# Altium schematic sheet 08_CF_INTERFACE.SchDoc
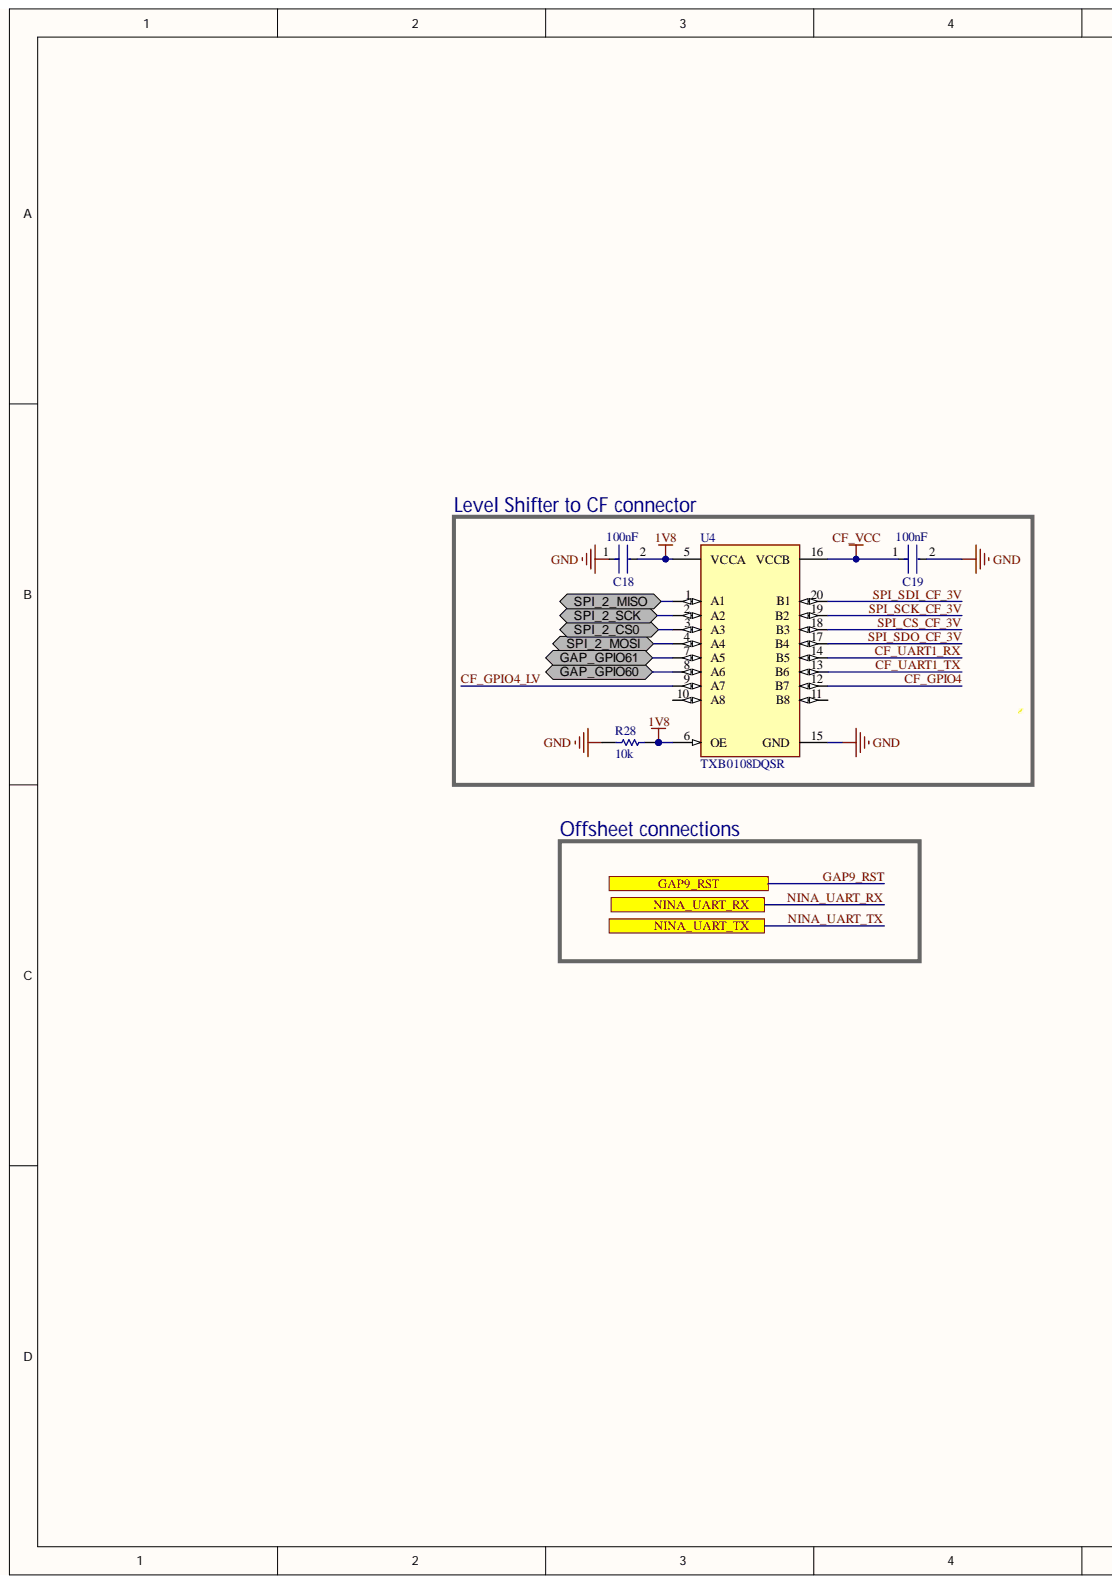
[diagram p0: 08_CF_INTERFACE.SchDoc, left]
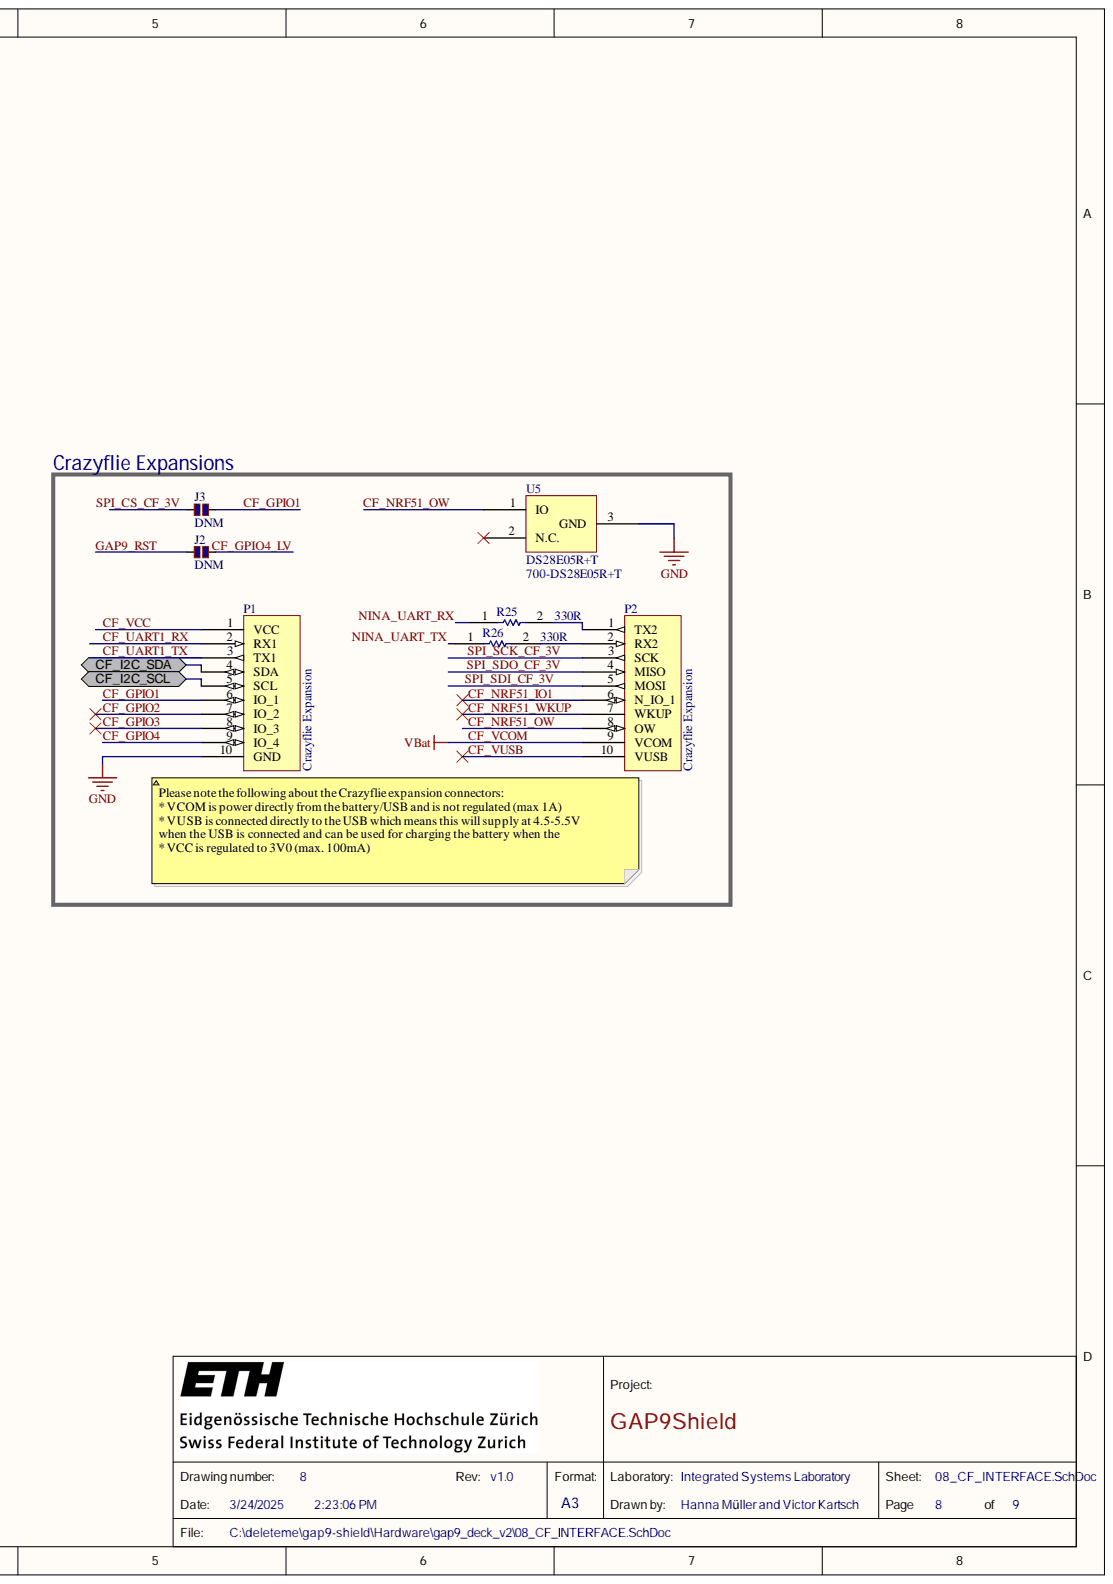
[diagram p1: 08_CF_INTERFACE.SchDoc, right]

## Parts
C18  value 100nF  Capacitance: 100nF  [FP-C1005-050-0_05-MFG]  (hidden comment: C1005X5R1E104K050BC)
C19  value 100nF  Capacitance: 100nF  [FP-C1005-050-0_05-MFG]  (hidden comment: C1005X5R1E104K050BC)
J2  DNM  [Small Solder Jumper]
J3  DNM  [Small Solder Jumper]
P1  Crazyflie Expansion  [CF2-DECK-SMD-HOLES]
P2  Crazyflie Expansion  [CF2-DECK-SMD-HOLES]
R25  value 330R  [H2C/H2R]  (hidden comment: ERJ-H2RD3300X)
R26  value 330R  [H2C/H2R]  (hidden comment: ERJ-H2RD3300X)
R28  value 10k  [RESC0603X28X15LL05T05]  (hidden comment: CRCW020110K0FNED)
U4  TXB0108DQSR  [FP-DQS0020A-MFG]
U5  DS28E05R+T  Supplier Part Number 1: 700-DS28E05R+T  [SOT23-3]

## Ports
CF_I2C_SCL, CF_I2C_SDA, GAP9_RST, GAP_GPIO60, GAP_GPIO61, NINA_UART_RX, NINA_UART_TX, SPI_2_CS0, SPI_2_MISO, SPI_2_MOSI, SPI_2_SCK

## Nets
1V8: C18.2 R28.1 U4.5(VCCA) U4.6(OE)
GND: C18.1 C19.2 P1.10(GND) R28.2 U4.15(GND) U5.3
CF_GPIO1: J3.1 P1.6(IO_1)
CF_GPIO2: P1.7(IO_2)
CF_GPIO3: P1.8(IO_3)
CF_GPIO4: P1.9(IO_4) U4.12(B7)
CF_GPIO4_LV: J2.1 U4.9(A7)
CF_I2C_SCL: P1.5(SCL) ; port CF_I2C_SCL
CF_I2C_SDA: P1.4(SDA) ; port CF_I2C_SDA
CF_NRF51_IO1: P2.6(N_IO_1)
CF_NRF51_OW: P2.8(OW) U5.1
CF_NRF51_WKUP: P2.7(WKUP)
CF_UART1_RX: P1.2(RX1) U4.14(B5)
CF_UART1_TX: P1.3(TX1) U4.13(B6)
CF_VCC: C19.1 P1.1(VCC) U4.16(VCCB)
CF_VCOM: P2.9(VCOM)
CF_VUSB: P2.10(VUSB)
GAP9_RST: J2.2 ; port GAP9_RST
GAP_GPIO60: U4.8(A6) ; port GAP_GPIO60
GAP_GPIO61: U4.7(A5) ; port GAP_GPIO61
NINA_UART_RX: R25.1 ; port NINA_UART_RX
NINA_UART_TX: R26.1 ; port NINA_UART_TX
SPI_2_CS0: U4.3(A3) ; port SPI_2_CS0
SPI_2_MISO: U4.1(A1) ; port SPI_2_MISO
SPI_2_MOSI: U4.4(A4) ; port SPI_2_MOSI
SPI_2_SCK: U4.2(A2) ; port SPI_2_SCK
SPI_CS_CF_3V: J3.2 U4.18(B3)
SPI_SCK_CF_3V: P2.3(SCK) U4.19(B2)
SPI_SDI_CF_3V: P2.5(MOSI) U4.20(B1)
SPI_SDO_CF_3V: P2.4(MISO) U4.17(B4)
NetP2_1: P2.1(TX2) R25.2
NetP2_2: P2.2(RX2) R26.2

Not connected: U4 10(A8) 11(B8); U5 2

## Library data of the parts
C18 C19 = CMP-2000-06000-2: Multilayer Ceramic Capacitors 100nF ±10% 25V X5R SMD 0402; Case Code (Imperial): 0402; Case Code (Metric): 1005; Case/Package: 0402; Component Kind: Standard; Construction: Flat; Contact Plating: Tin; Depth: 0.5mm; Dielectric: X5R; Dielectric Material: Ceramic; Height: 0.5mm; Lead Free: Lead Free; Length: 1mm; Library Name: Altium Content Vault; Max Operating Temperature: 85°C; Min Operating Temperature: -55°C; Mount: Surface Mount; Package Quantity: 1; Packaging: Tape and Reel; REACH SVHC: No SVHC; Resistor Type: Ceramic; RoHS Compliant: Yes; Series: C; Termination: Wraparound; Thickness: 0.022inch; Tolerance: 10%; Voltage: 25V; Voltage Rating: 25V; Voltage Rating (DC): 25V; Weight: 2.3E-05oz; Width: 0.02inch
J2 J3 = CMP-00005-5: Component Kind: Standard; Library Name: ETHZ Vault; Simulation: SIM-001-0001-1; Technology: SMT
P1 = CF_EXP_LEFT: Component Kind: Standard; Library Name: Crazyflie.SchLib; Supplier 1: Digi-Key; Supplier Part Number 1: 110990442-ND
P2 = CF_EXP_RIGHT: Component Kind: Standard; Library Name: Crazyflie.SchLib; Supplier 1: Digi-Key; Supplier Part Number 1: 110990442-ND
R25 R26 = ERJ-H2RD3300X: Resistor; Manufacturer_Name: Panasonic; Component Kind: Standard; Datasheet Link: http://industrial.panasonic.com/cdbs/www-data/pdf/RDQ0000/ast-ind-151033.pdf; Library Name: SamacSys.SchLib; Manufacturer_Part_Number: ERJ-H2RD3300X; Mouser Part Number: 667-ERJ-H2RD3300X; Mouser Price/Stock: https://www.mouser.co.uk/ProductDetail/Panasonic/ERJ-H2RD3300X?qs=eP2BKZSCXI6Pijj457e9wg%3D%3D
R28 = CMP-2004-00016-1: Manufacturer: Vishay Dale; Component Kind: Standard; Library Name: Altium Content Vault; Part Number: CRCW020110K0FNED
U4 = CMP-04899-000009-1: IC TRNSLTR BIDIRECTIONAL 20USON; Manufacturer: Texas Instruments; Data Rate: 100Mbits/s; Logic Function Desc: Non-Inverting; Max Junction Temp: +150°C; Max Supply Voltage: 5.5V; Min Supply Voltage: 1.2V; Nominal Supply Current: 0.05-3.5uA; Number Of Circuits: 8; Number Of Inputs: 1; Propagation Delay: 4ns; Standoff Height: 0mm; V A Max: 3.6V; V B Min: 1.65V; Ambient Temperature Range High: +85°C; Ambient Temperature Range Low: -40°C; Automotive: No; Category: IC; Component Kind: Standard; ComponentLink2Description: Datasheet; ComponentLink2URL: https://www.ti.com/lit/ds/symlink/txb0108.pdf; Device Class L1: Integrated Circuits (ICs); Device Class L2: Logic ICs; Device Class L3: Voltage Level Shifters; Digikey Description: IC TRNSLTR BIDIRECTIONAL 20USON; Height: 0.55mm; Lead Free: Yes; Library Name: Altium Content Vault; Manufacturer Part Number: TXB0108DQSR; Mouser Description: Translation - Voltage Levels 8B Bidir Vltg-Level Translator; Number Of Outputs: 1; Octopart: https://octopart.com/txb0108dqsr-texas+instruments-18562890; Package: DQS0020A; RoHS: TRUE; Temperature: -40°C to +85°C; Temperature Range High: +85°C; Temperature Range Low: -40°C; V A Min: 1.2V; V B Max: 5.5V
U5 = DS28E05R+T: EEPROM 1-Wire 112-BYTE; Component Kind: Standard; Library Name: EEPROM.SchLib; Supplier 1: Mouser
